# Altium schematic sheet AMS_LV_6.SchDoc
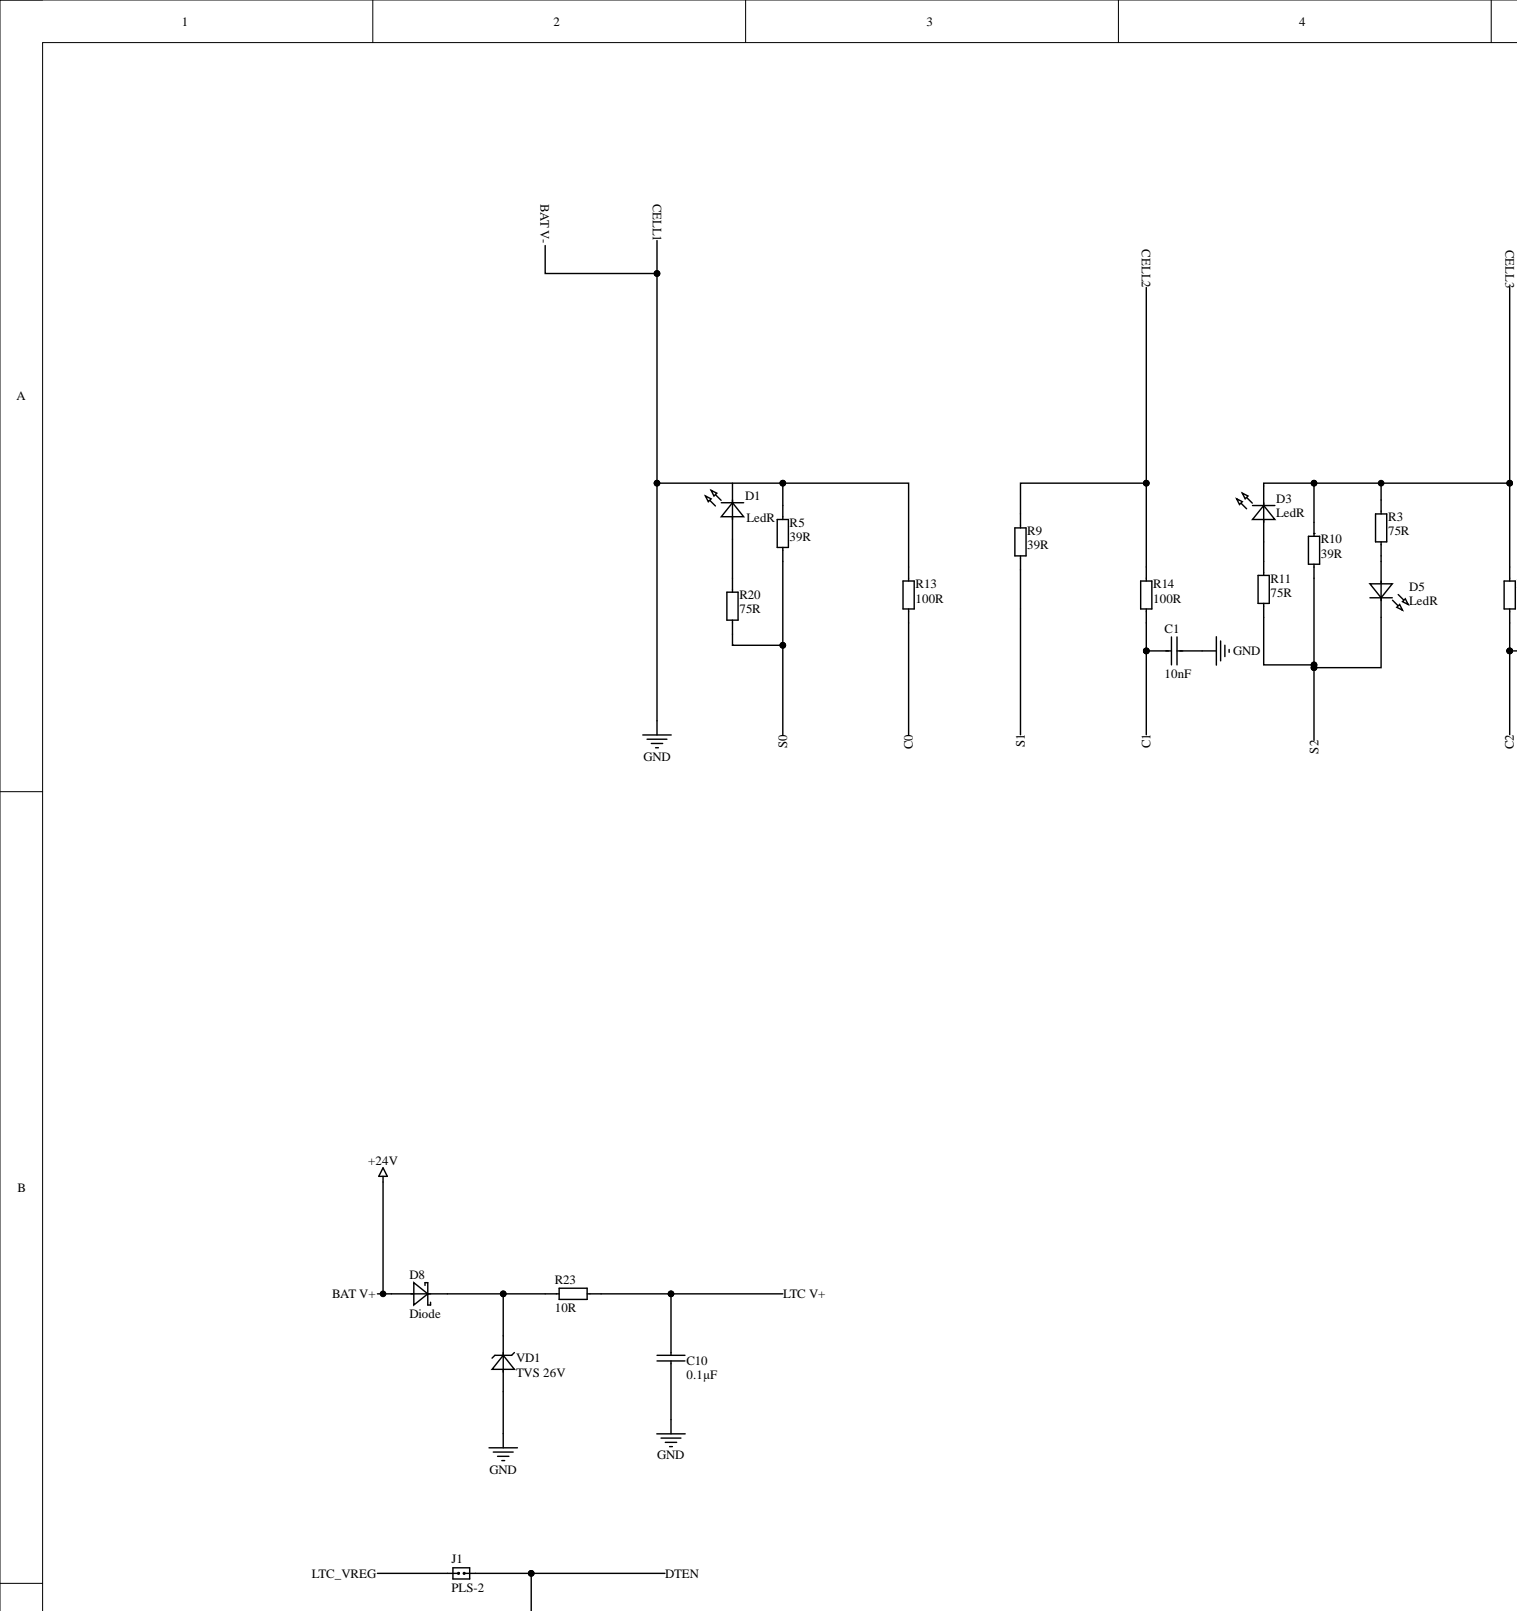
[diagram p0: AMS_LV_6.SchDoc, top left]
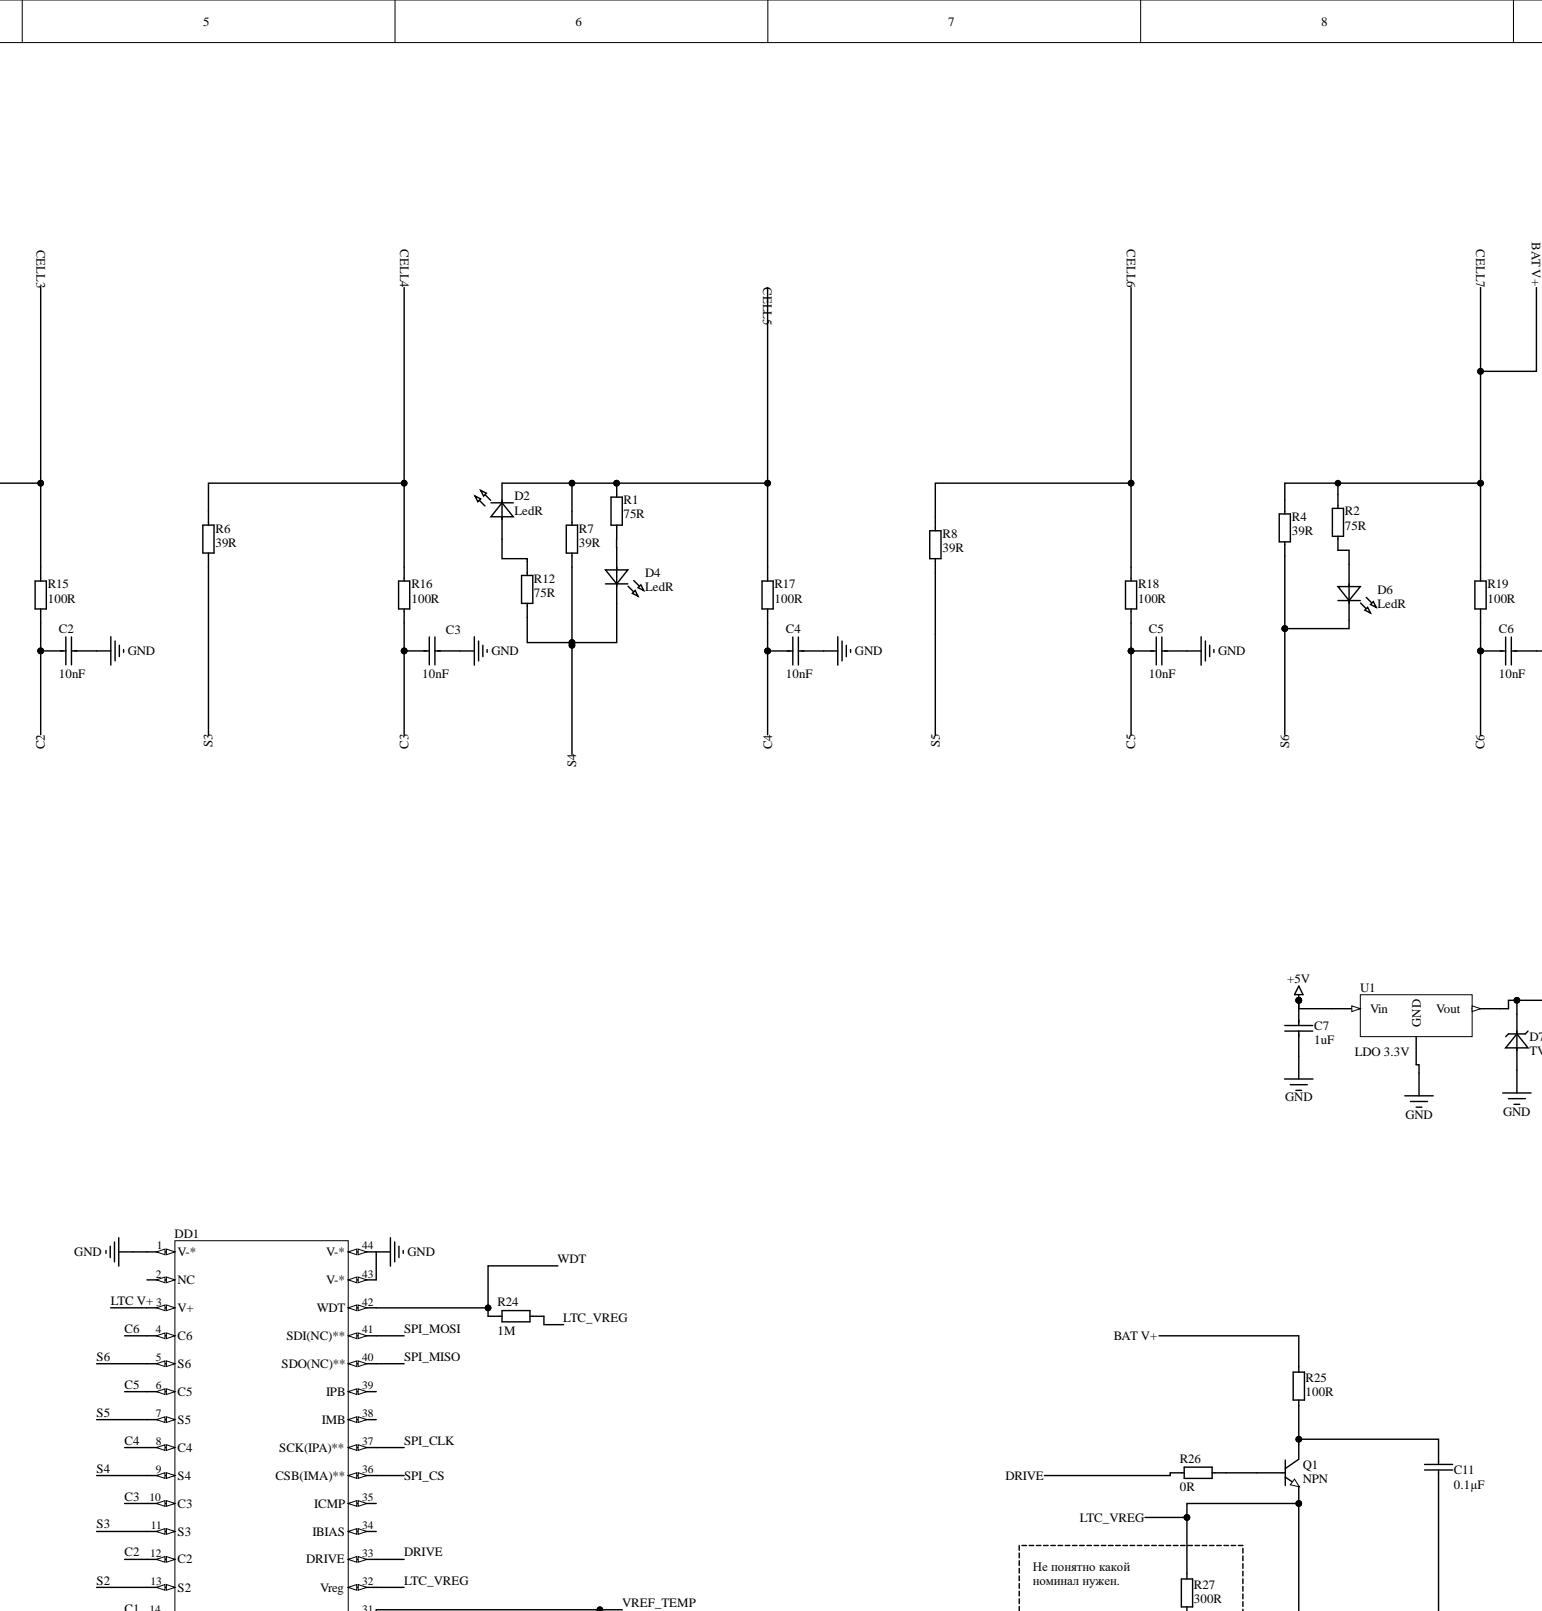
[diagram p1: AMS_LV_6.SchDoc, top centre]
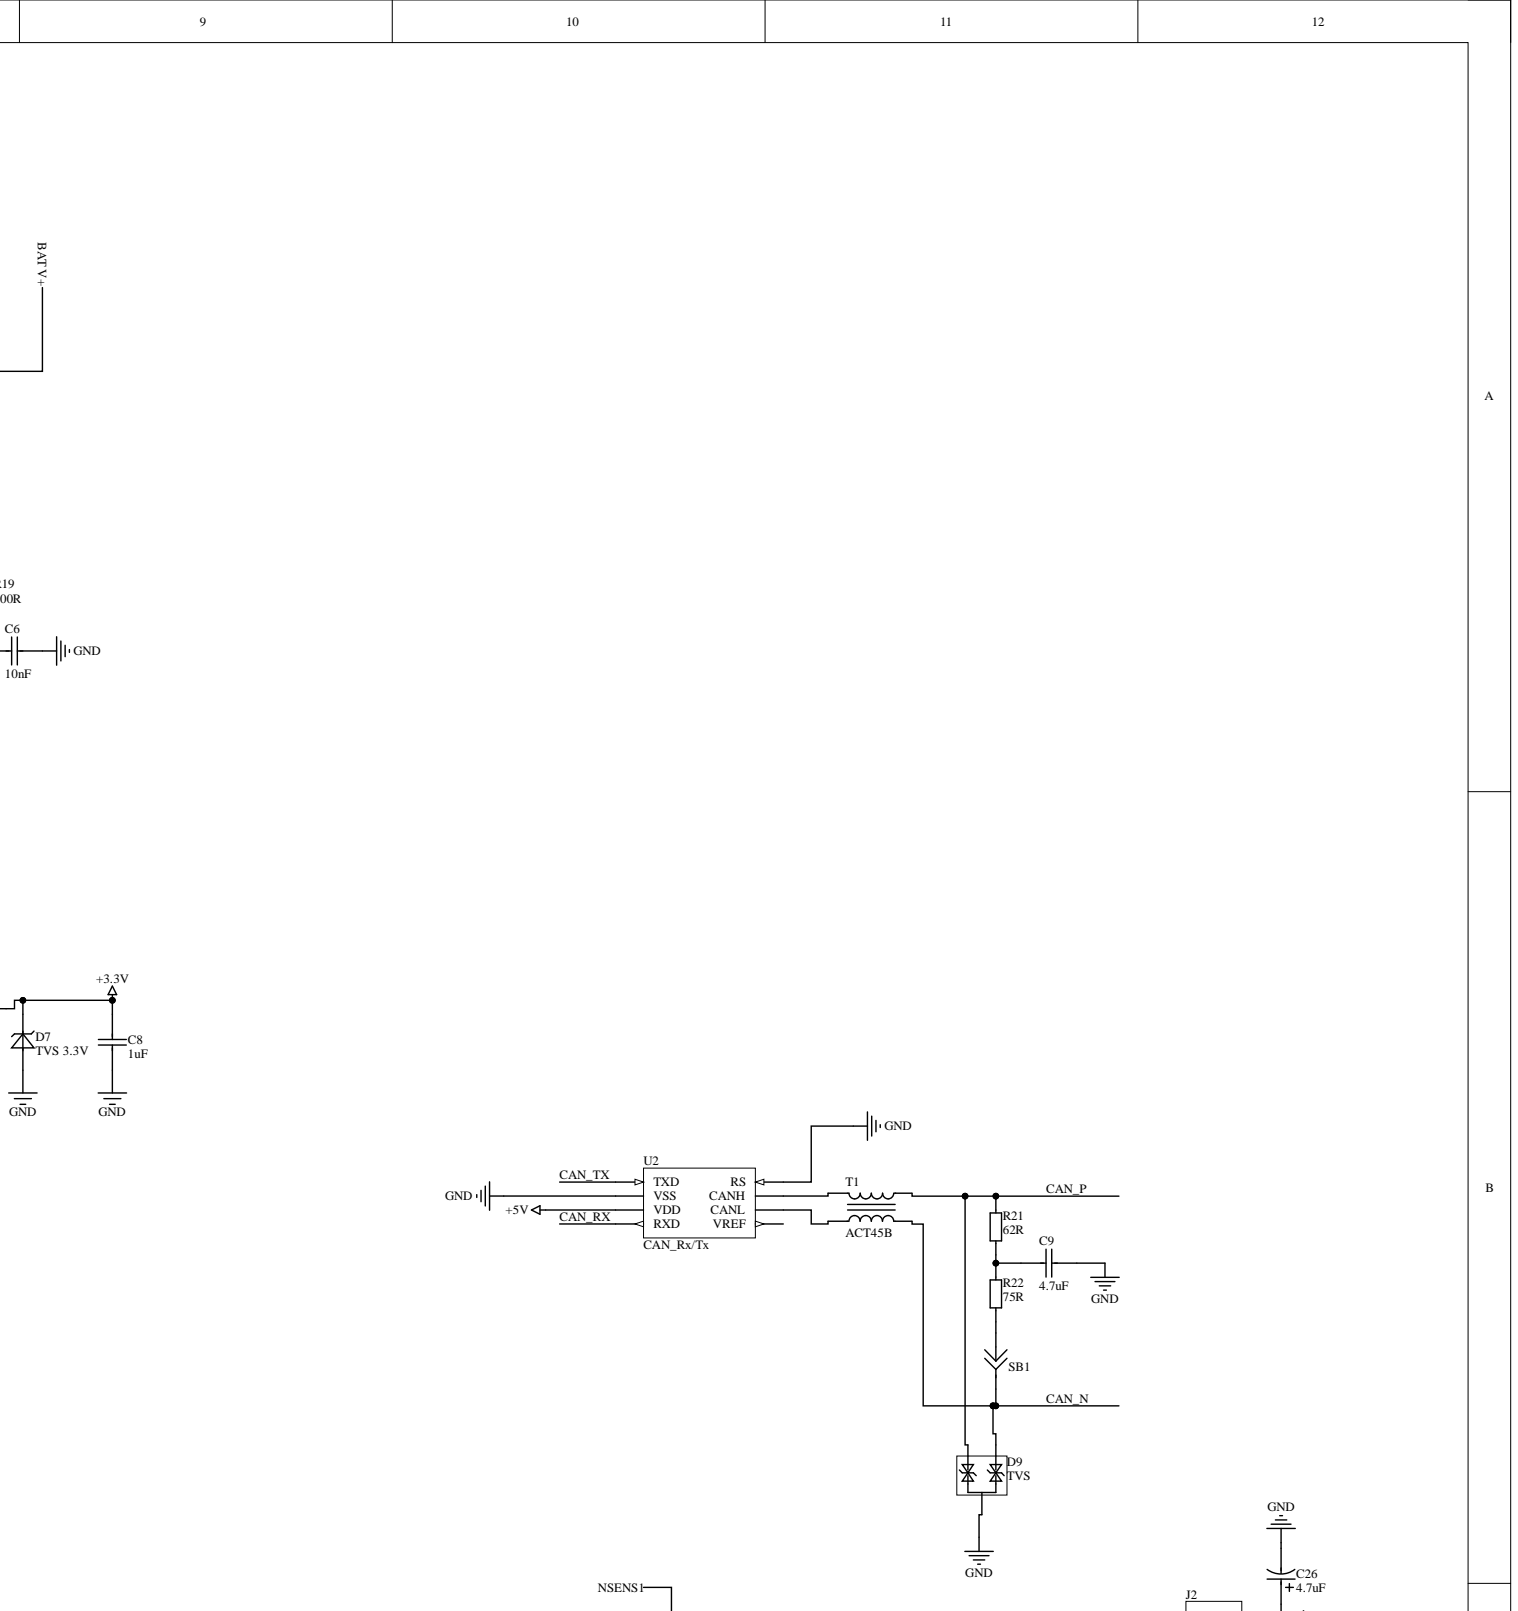
[diagram p2: AMS_LV_6.SchDoc, top right]
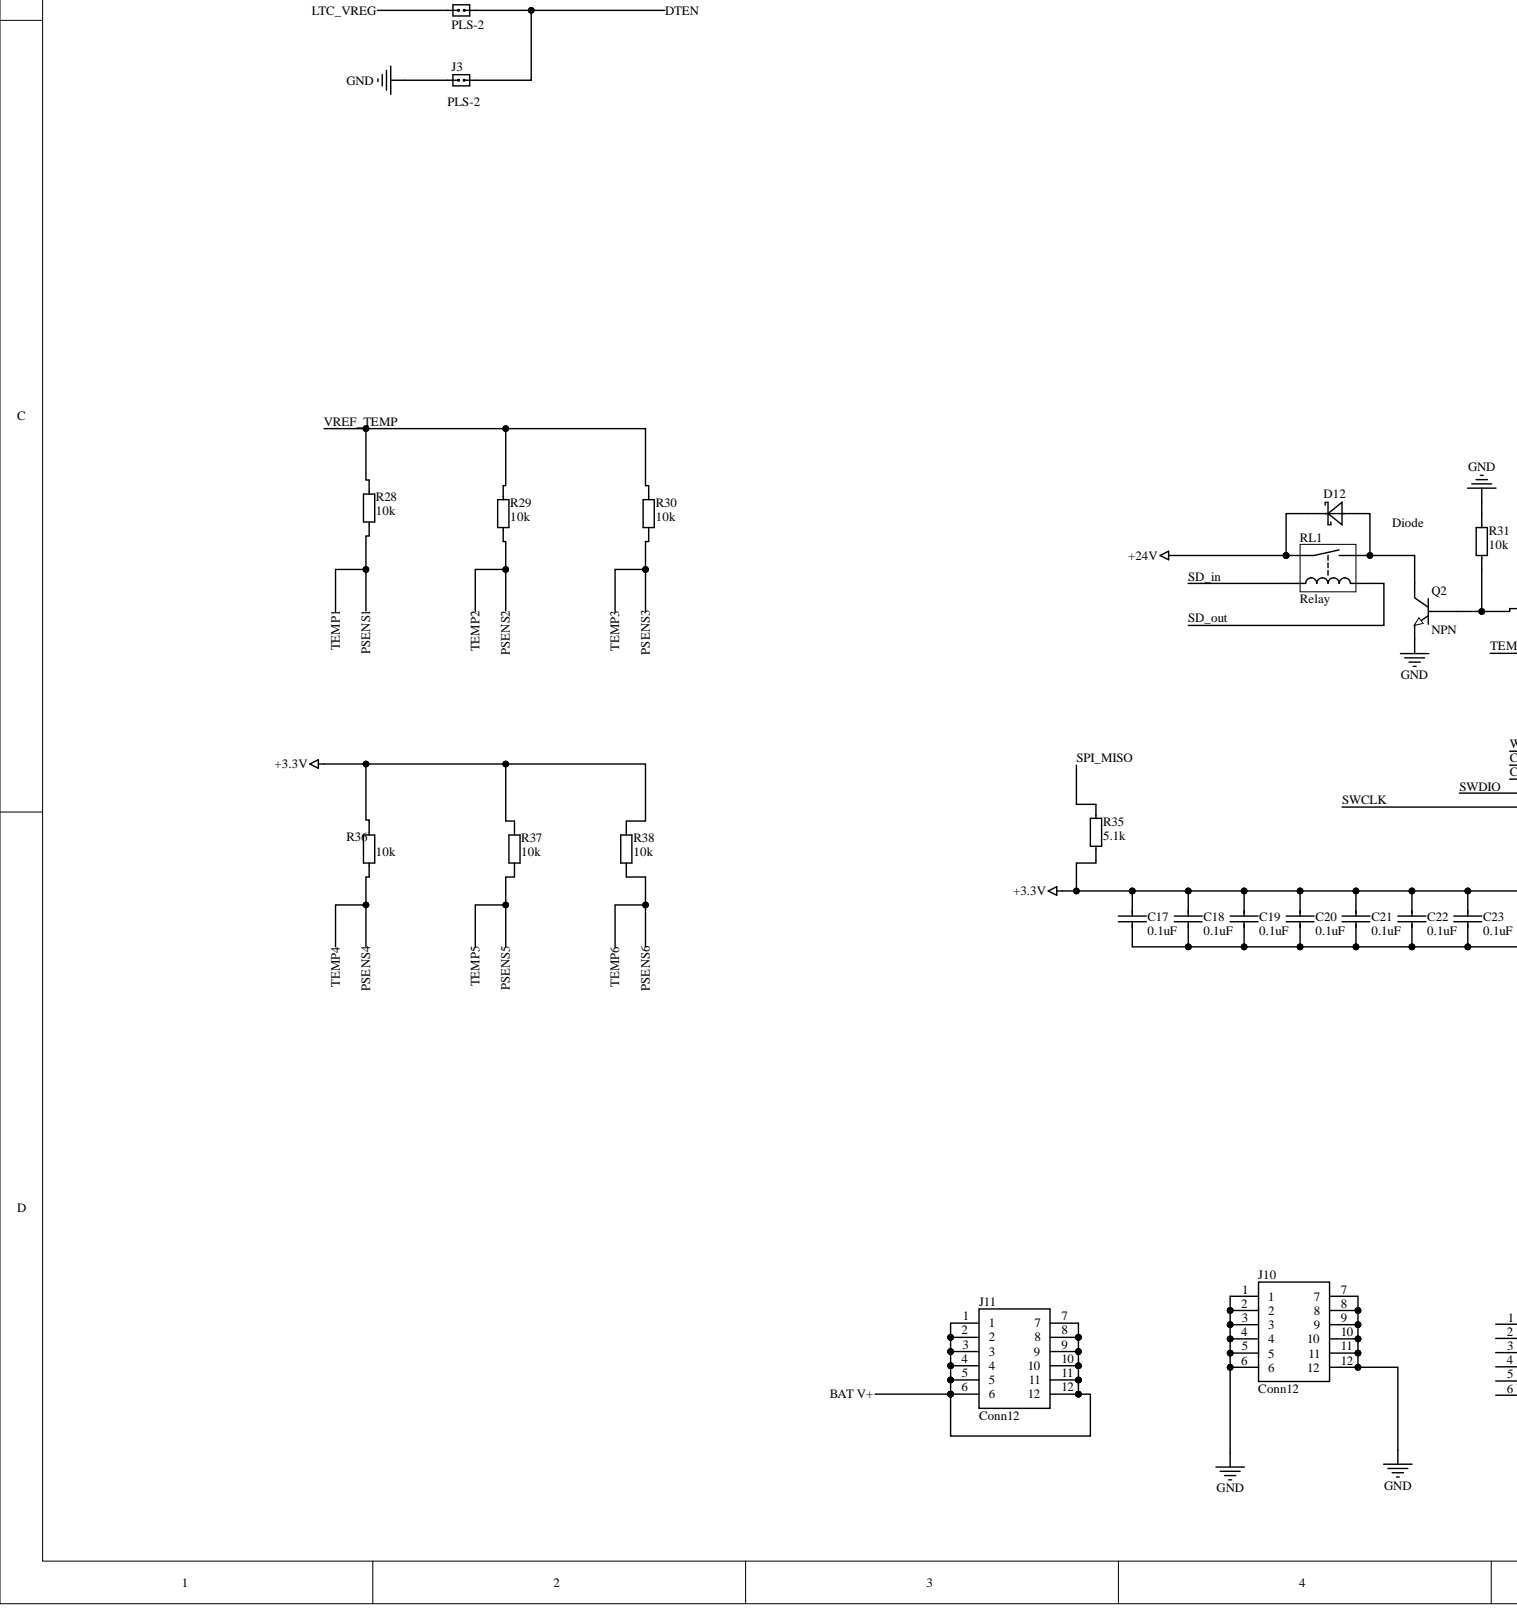
[diagram p3: AMS_LV_6.SchDoc, bottom left]
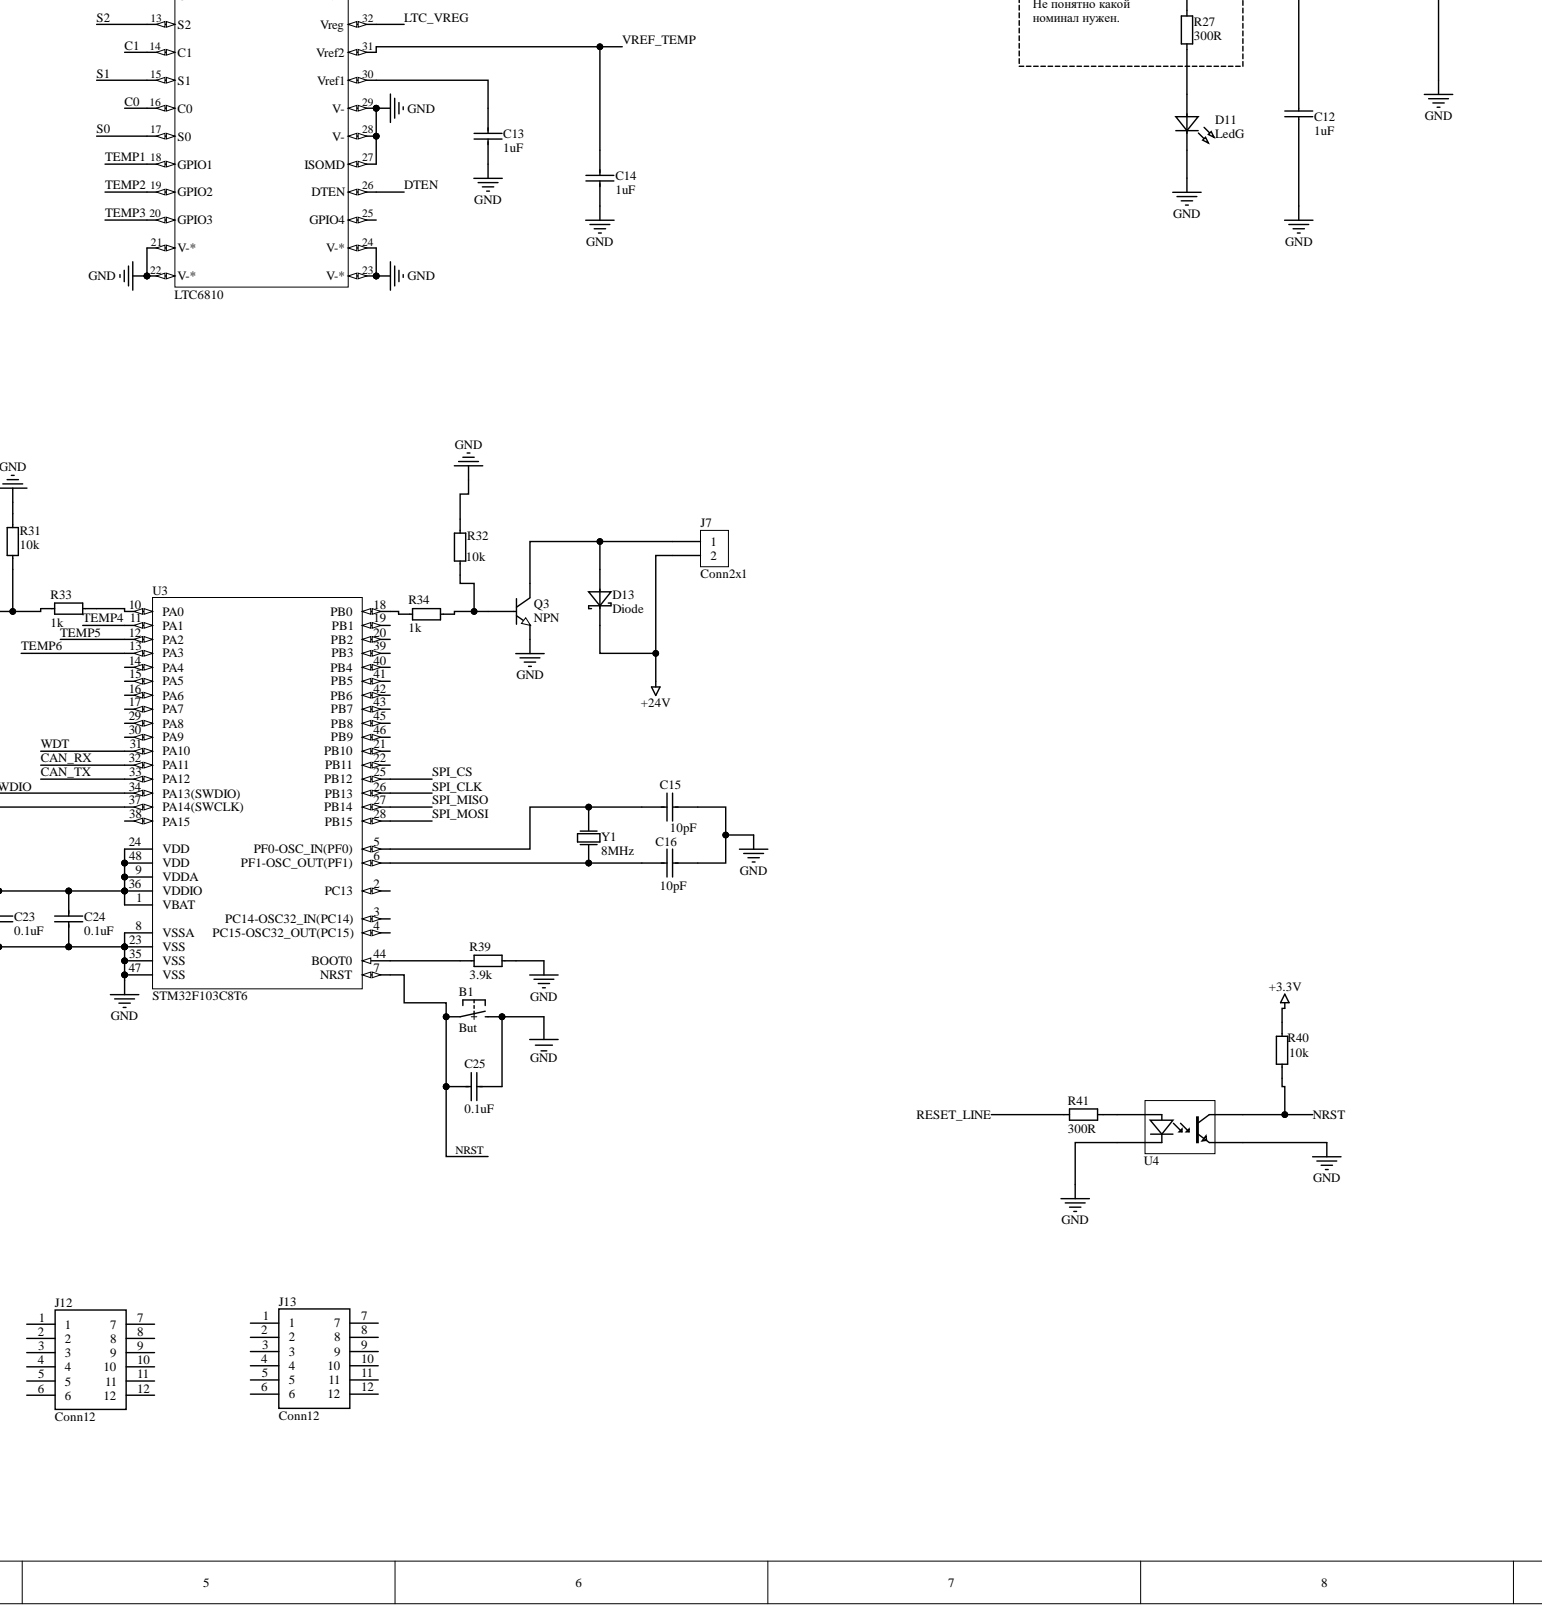
[diagram p4: AMS_LV_6.SchDoc, bottom centre]
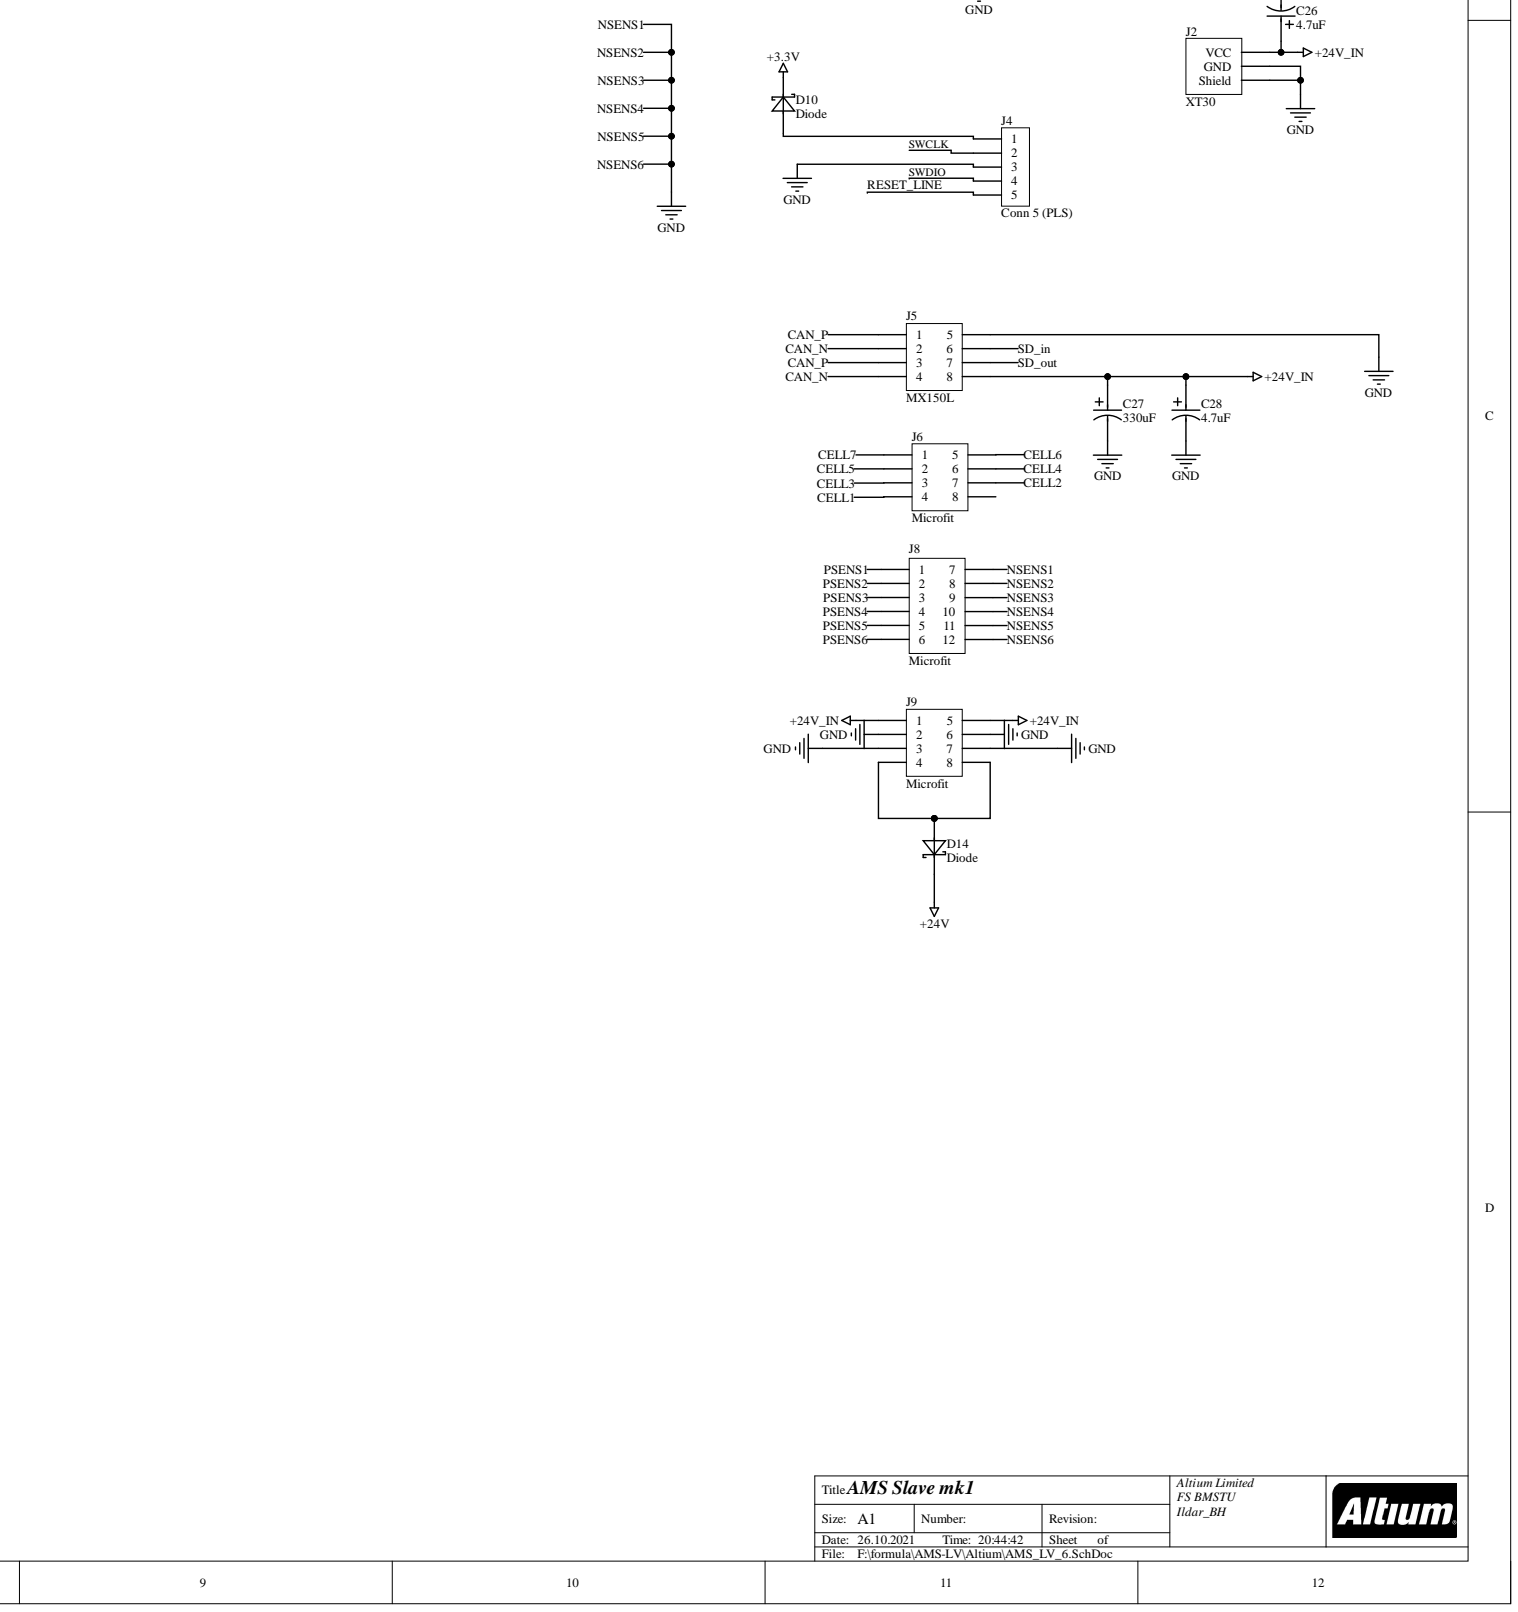
[diagram p5: AMS_LV_6.SchDoc, bottom right]

## Parts
B1  But  [BUTTON 4x3]
C1  10nF  [0603 Capicator]
C2  10nF  [0603 Capicator]
C3  10nF  [0603 Capicator]
C4  10nF  [0603 Capicator]
C5  10nF  [0603 Capicator]
C6  10nF  [0603 Capicator]
C7  1uF  [0603 Capicator]
C8  1uF  [0603 Capicator]
C9  4.7uF  [0603 Capicator]
C10  0.1µF  [Cap 0.1µF (1206)]
C11  0.1µF  [Cap 0.1µF (1206)]
C12  1uF  [Cap 0.1µF (1206)]
C13  1uF  [0603 Capicator]
C14  1uF  [0603 Capicator]
C15  10pF  [0603 Capicator]
C16  10pF  [0603 Capicator]
C17  0.1uF  [0603 Capicator]
C18  0.1uF  [0603 Capicator]
C19  0.1uF  [0603 Capicator]
C20  0.1uF  [0603 Capicator]
C21  0.1uF  [0603 Capicator]
C22  0.1uF  [0603 Capicator]
C23  0.1uF  [0603 Capicator]
C24  0.1uF  [0603 Capicator]
C25  0.1uF  [0603 Capicator]
C26  4.7uF  [Bar Cap 4x5.4]
C27  330uF  [Bar Cap 8x10.5]
C28  4.7uF  [Bar Cap 4x5.4]
D1  LedR  [0805 LED]
D2  LedR  [0805 LED]
D3  LedR  [0805 LED]
D4  LedR  [0805 LED]
D5  LedR  [0805 LED]
D6  LedR  [0805 LED]
D7  TVS 3.3V  [DO-216AA]
D8  Diode  [SMB]
D9  TVS  [SOT-23]
D10  Diode  [sod-123]
D11  LedG  [0805 LED]
D12  Diode  [SMA]
D13  Diode  [SMA]
D14  Diode  [TO-220-3]
DD1  LTC6810  [LTC6810-1]
J1  PLS-2  [Conn PLS-2]
J2  XT30  [HEADER 2 XT30PW Male]
J3  PLS-2  [Conn PLS-2]
J4  Conn 5 (PLS)  [PLS 5x1]
J5  MX150L  [Conn 4x2 [MX150L]]
J6  Microfit  [Microfit_4x2]
J7  Conn2x1  [MolexMicroFit 2x1]
J8  Microfit  [Microfit_6x2]
J9  Microfit  [Microfit_4x2]
J10  Conn12  [7460408]
J11  Conn12  [7460408]
J12  Conn12  [7460408]
J13  Conn12  [7460408]
Q1  NPN  [SOT-223]
Q2  NPN  [SOT-223]
Q3  NPN  [SOT-223]
R1  75R  [0805 Resistor]
R2  75R  [0805 Resistor]
R3  75R  [0805 Resistor]
R4  39R  [RES 39R (2512)]
R5  39R  [RES 39R (2512)]
R6  39R  [RES 39R (2512)]
R7  39R  [RES 39R (2512)]
R8  39R  [RES 39R (2512)]
R9  39R  [RES 39R (2512)]
R10  39R  [RES 39R (2512)]
R11  75R  [0805 Resistor]
R12  75R  [0805 Resistor]
R13  100R  [RES 100R (603)]
R14  100R  [RES 100R (603)]
R15  100R  [RES 100R (603)]
R16  100R  [RES 100R (603)]
R17  100R  [RES 100R (603)]
R18  100R  [RES 100R (603)]
R19  100R  [RES 100R (603)]
R20  75R  [0805 Resistor]
R21  62R  [0603 Resistor]
R22  75R  [0805 Resistor]
R23  10R  [RES 10R (603)]
R24  1M  [0805 Resistor]
R25  100R  [Res 100R (1206)]
R26  0R  [0603 Resistor]
R27  300R  [Res 300R (603)]
R28  10k  [0603 Resistor]
R29  10k  [0603 Resistor]
R30  10k  [0603 Resistor]
R31  10k  [0603 Resistor]
R32  10k  [0603 Resistor]
R33  1k  [0603 Resistor]
R34  1k  [0603 Resistor]
R35  5.1k  [RES 5.1k (603)]
R36  10k  [0603 Resistor]
R37  10k  [0603 Resistor]
R38  10k  [0603 Resistor]
R39  3.9k  [0603 Resistor]
R40  10k  [0603 Resistor]
R41  300R  [Res 300R (603)]
RL1  Relay  [Relay(RE030024)]
SB1  Circuit Breaker  [SB 0603]  (value not drawn)
T1  ACT45B  [ACT45B]
U1  LDO 3.3V  [SOT-23]
U2  CAN_Rx/Tx  [SO-8]
U3  STM32F103C8T6  [LQFP48]
U4  Opt  [Optocupler [LTV-817S]]  (value not drawn)
VD1  TVS 26V  [TVS Diode 26V [SMF26A]]
Y1  8MHz  [hc-49sm]

## Nets
+3.3V: C8.2 C17.2 C18.2 C19.2 C20.2 C21.2 C22.2 C23.2 C24.2 D7.2 D10.2 R35.1 R36.2 R37.2 R38.2 R40.2 U1.2 U3.1(VBAT) U3.9(VDDA) U3.24(VDD) U3.36(VDDIO) U3.48(VDD)
+5V: C7.2 U1.3 U2.3(VDD)
+24V_IN: C26.2 C27.2 C28.2 J2.1 J5.8 J9.1 J9.5
BAT V+: D8.1 D12.2 D13.2 D14.2 J6.1 J7.2 J11.1 J11.2 J11.3 J11.4 J11.5 J11.6 J11.7 J11.8 J11.9 J11.10 J11.11 J11.12 R2.2 R4.2 R19.2 R25.2 RL1.4
BAT V-: B1.2 C1.2 C2.2 C3.2 C4.2 C5.2 C6.2 C7.1 C8.1 C9.2 C10.2 C11.2 C12.2 C13.1 C14.1 C15.2 C16.2 C17.1 C18.1 C19.1 C20.1 C21.1 C22.1 C23.1 C24.1 C25.1 C26.1 C27.1 C28.1 D1.2 D7.1 D9.3 D11.2 DD1.1(V-*) DD1.21(V-*) DD1.22(V-*) DD1.23(V-*) DD1.24(V-*) DD1.27(ISOMD) DD1.28(V-) DD1.29(V-) DD1.43(V-*) DD1.44(V-*) J2.2 J2.A J3.2 J4.3 J5.5 J6.4 J8.7 J8.8 J8.9 J8.10 J8.11 J8.12 J9.2 J9.3 J9.6 J9.7 J10.1 J10.2 J10.3 J10.4 J10.5 J10.6 J10.7 J10.8 J10.9 J10.10 J10.11 J10.12 Q2.3 Q3.3 R5.2 R13.2 R31.2 R32.2 R39.2 U1.1 U2.2(VSS) U2.8(RS) U3.8(VSSA) U3.23(VSS) U3.35(VSS) U3.47(VSS) U4.2 U4.3 VD1.2
C0: DD1.16(C0) R13.1
C1: C1.1 DD1.14(C1) R14.1
C2: C2.1 DD1.12(C2) R15.1
C3: C3.1 DD1.10(C3) R16.1
C4: C4.1 DD1.8(C4) R17.1
C5: C5.1 DD1.6(C5) R18.1
C6: C6.1 DD1.4(C6) R19.1
CAN_N: D9.1 J5.2 J5.4 SB1.1 T1.3
CAN_P: D9.2 J5.1 J5.3 R21.2 T1.4
CAN_RX: U2.4(RXD) U3.32(PA11)
CAN_TX: U2.1(TXD) U3.33(PA12)
CELL2: J6.7 R9.2 R14.2
CELL3: D3.2 J6.3 R3.2 R10.2 R15.2
CELL4: J6.6 R6.2 R16.2
CELL5: D2.2 J6.2 R1.2 R7.2 R17.2
CELL6: J6.5 R8.2 R18.2
DRIVE: DD1.33(DRIVE) R26.1
DTEN: DD1.26(DTEN) J1.1 J3.1
LTC V+: C10.1 DD1.3(V+) R23.2
LTC_VREG: C12.1 DD1.32(Vreg) J1.2 Q1.3 R24.2 R27.1
NRST: B1.1 C25.2 R40.1 U3.7(NRST) U4.4
PSENS1: DD1.18(GPIO1) J8.1 R28.1
PSENS2: DD1.19(GPIO2) J8.2 R29.1
PSENS3: DD1.20(GPIO3) J8.3 R30.1
PSENS4: J8.4 R36.1 U3.11(PA1)
PSENS5: J8.5 R37.1 U3.12(PA2)
PSENS6: J8.6 R38.1 U3.13(PA3)
RESET_LINE: J4.5 R41.1
S0: DD1.17(S0) R5.1 R20.1
S1: DD1.15(S1) R9.1
S2: D5.2 DD1.13(S2) R10.1 R11.1
S3: DD1.11(S3) R6.1
S4: D4.2 DD1.9(S4) R7.1 R12.1
S5: DD1.7(S5) R8.1
S6: D6.2 DD1.5(S6) R4.1
SD_in: J5.6 RL1.1
SD_out: J5.7 RL1.2
SPI_CLK: DD1.37(SCK(IPA)**) U3.26(PB13)
SPI_CS: DD1.36(CSB(IMA)**) U3.25(PB12)
SPI_MISO: DD1.40(SDO(NC)**) R35.2 U3.27(PB14)
SPI_MOSI: DD1.41(SDI(NC)**) U3.28(PB15)
SWCLK: J4.2 U3.37(PA14(SWCLK))
SWDIO: J4.4 U3.34(PA13(SWDIO))
VREF_TEMP: C14.2 DD1.31(Vref2) R28.2 R29.2 R30.2
WDT: DD1.42(WDT) R24.1 U3.31(PA10)
NetC9_1: C9.1 R21.1 R22.2
NetC11_1: C11.1 Q1.2 R25.1
NetC13_2: C13.2 DD1.30(Vref1)
NetC15_1: C15.1 U3.5(PF0-OSC_IN(PF0)) Y1.2
NetC16_1: C16.1 U3.6(PF1-OSC_OUT(PF1)) Y1.1
NetD1_1: D1.1 R20.2
NetD2_1: D2.1 R12.2
NetD3_1: D3.1 R11.2
NetD4_1: D4.1 R1.1
NetD5_1: D5.1 R3.1
NetD6_1: D6.1 R2.1
NetD8_2: D8.2 R23.1 VD1.1
NetD10_1: D10.1 J4.1
NetD11_1: D11.1 R27.2
NetD12_1: D12.1 Q2.2 RL1.3
NetD13_1: D13.1 J7.1 Q3.2
NetD14_1: D14.1 J9.4 J9.8
NetQ1_1: Q1.1 R26.2
NetQ2_1: Q2.1 R31.1 R33.2
NetQ3_1: Q3.1 R32.1 R34.1
NetR22_1: R22.1 SB1.2
NetR33_1: R33.1 U3.10(PA0)
NetR34_2: R34.2 U3.18(PB0)
NetR39_1: R39.1 U3.44(BOOT0)
NetR41_2: R41.2 U4.1
NetT1_1: T1.1 U2.7(CANH)
NetT1_2: T1.2 U2.6(CANL)

Not connected: DD1 2(NC) 25(GPIO4) 34(IBIAS) 35(ICMP) 38(IMB) 39(IPB); J6 8; J12 1 2 3 4 5 6 7 8 9 10 11 12; J13 1 2 3 4 5 6 7 8 9 10 11 12; U2 5(VREF); U3 2(PC13) 3(PC14-OSC32_IN(PC14)) 4(PC15-OSC32_OUT(PC15)) 14(PA4) 15(PA5) 16(PA6) 17(PA7) 19(PB1) 20(PB2) 21(PB10) 22(PB11) 29(PA8) 30(PA9) 38(PA15) 39(PB3) 40(PB4) 41(PB5) 42(PB6) 43(PB7) 45(PB8) 46(PB9)
